# Altium schematic sheet ATK-MD0240.SchDoc
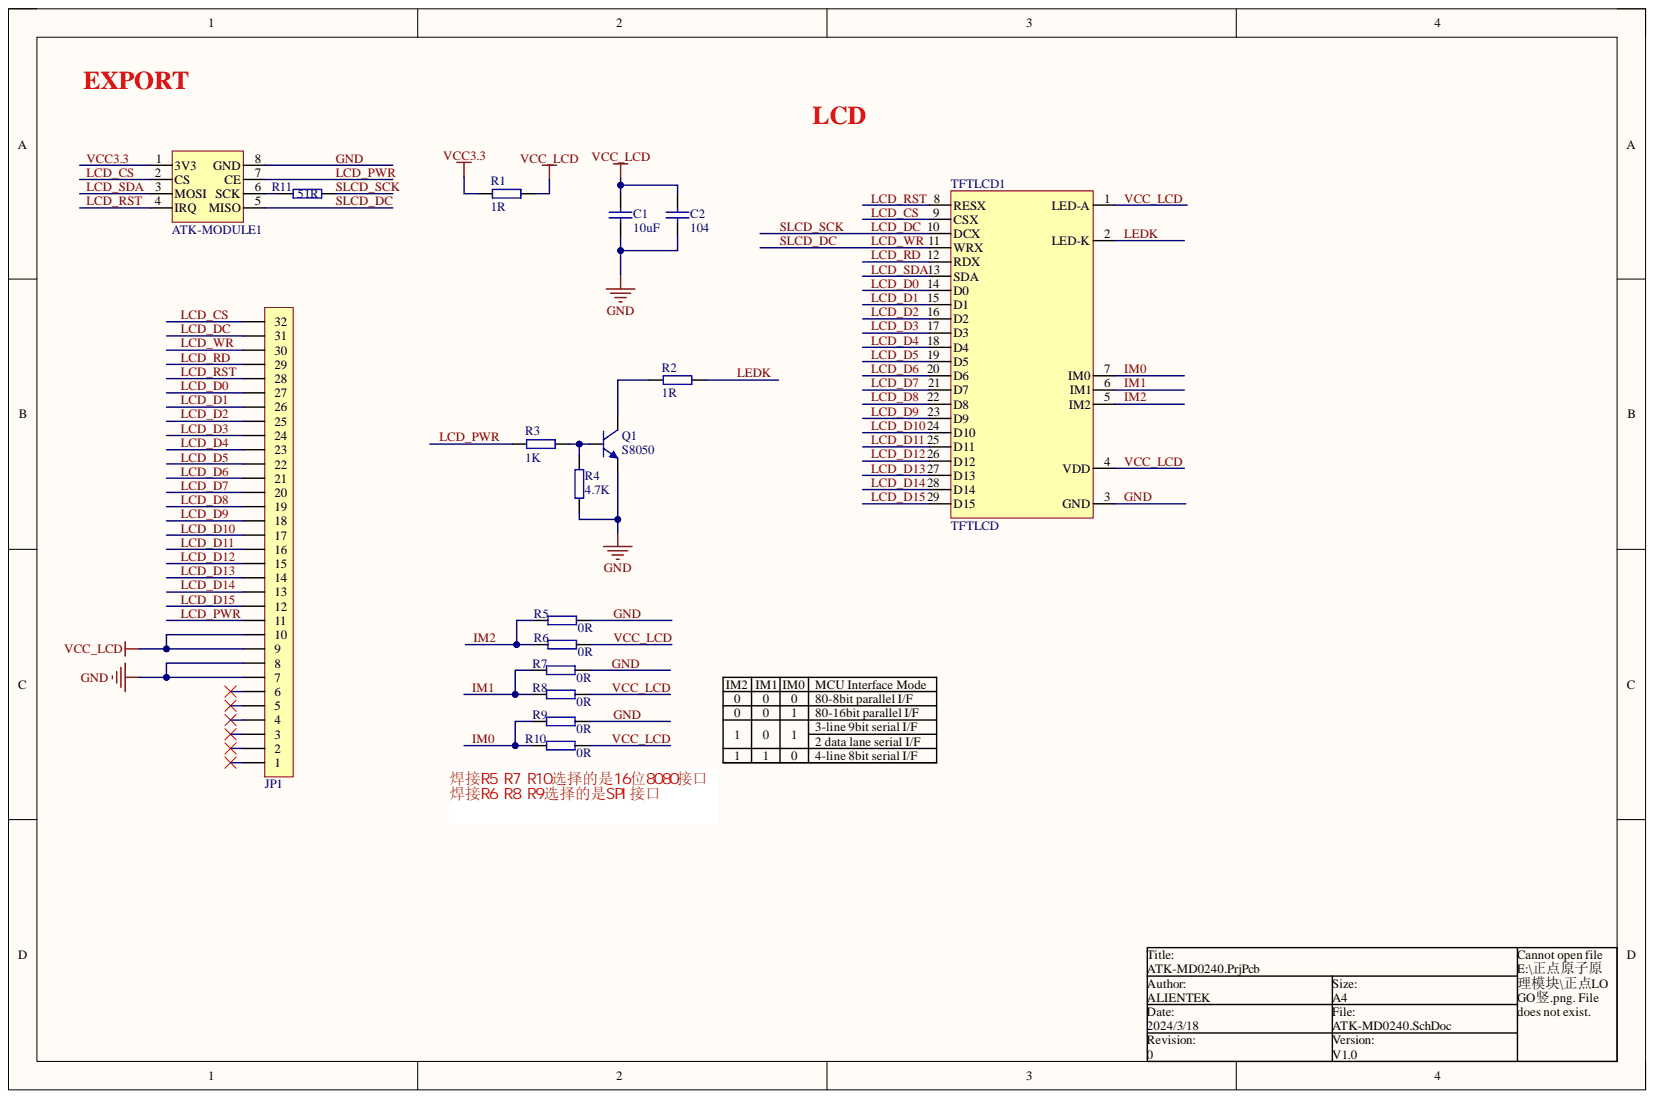
[diagram p0: ATK-MD0240.SchDoc]

## Parts
ATK-MODULE1  J-ATK-WIRELESS  [HEADER-2*4-2.54MM]  (value not drawn)
C1  10uF  [C 0402]
C2  104  [C 0402]
JP1  FPC1.0-32P  [FPC 0.5 32P H-S]  (value not drawn)
Q1  S8050  [SOT-23-3]
R1  1R  [R 0402]
R2  1R  [R 0402]
R3  1K  [R 0402]
R4  4.7K  [R 0402]
R5  0R  [R 0402]
R6  0R  [R 0402]
R7  0R  [R 0402]
R8  0R  [R 0402]
R9  0R  [R 0402]
R10  0R  [R 0402]
R11  51R  [R 0402]
TFTLCD1  TFTLCD  [SPI_LCD2.4_29pin]

## Nets
GND: ATK-MODULE1.8(GND) C1.2 C2.2 JP1.7 JP1.8 Q1.2 R4.1 R5.2 R7.2 R9.2 TFTLCD1.3(GND)
IM0: R9.1 R10.1 TFTLCD1.7(IM0)
IM1: R7.1 R8.1 TFTLCD1.6(IM1)
IM2: R5.1 R6.1 TFTLCD1.5(IM2)
LCD_CS: ATK-MODULE1.2(CS) JP1.32 TFTLCD1.9(CSX)
LCD_D0: JP1.27 TFTLCD1.14(D0)
LCD_D1: JP1.26 TFTLCD1.15(D1)
LCD_D2: JP1.25 TFTLCD1.16(D2)
LCD_D3: JP1.24 TFTLCD1.17(D3)
LCD_D4: JP1.23 TFTLCD1.18(D4)
LCD_D5: JP1.22 TFTLCD1.19(D5)
LCD_D6: JP1.21 TFTLCD1.20(D6)
LCD_D7: JP1.20 TFTLCD1.21(D7)
LCD_D8: JP1.19 TFTLCD1.22(D8)
LCD_D9: JP1.18 TFTLCD1.23(D9)
LCD_D10: JP1.17 TFTLCD1.24(D10)
LCD_D11: JP1.16 TFTLCD1.25(D11)
LCD_D12: JP1.15 TFTLCD1.26(D12)
LCD_D13: JP1.14 TFTLCD1.27(D13)
LCD_D14: JP1.13 TFTLCD1.28(D14)
LCD_D15: JP1.12 TFTLCD1.29(D15)
LCD_DC: JP1.31 R11.2 TFTLCD1.10(DCX)
LCD_PWR: ATK-MODULE1.7(CE) JP1.11 R3.1
LCD_RD: JP1.29 TFTLCD1.12(RDX)
LCD_RST: ATK-MODULE1.4(IRQ) JP1.28 TFTLCD1.8(RESX)
LCD_SDA: ATK-MODULE1.3(MOSI) TFTLCD1.13(SDA)
LCD_WR: ATK-MODULE1.5(MISO) JP1.30 TFTLCD1.11(WRX)
LEDK: R2.2 TFTLCD1.2(LED-K)
VCC3.3: ATK-MODULE1.1(3V3) R1.1
VCC_LCD: C1.1 C2.1 JP1.9 JP1.10 R1.2 R6.2 R8.2 R10.2 TFTLCD1.1(LED-A) TFTLCD1.4(VDD)
NetATK-MODULE1_6: ATK-MODULE1.6(SCK) R11.1
NetQ1_1: Q1.1 R3.2 R4.2
NetQ1_3: Q1.3 R2.1

Not connected: JP1 1 2 3 4 5 6

## Library data of the parts
ATK-MODULE1 = J-ATK-WIRELESS: ALIENTEK无线接口; Component Kind: Standard; Library Name: ATK-MD0240.SCHLIB
C1 C2 = CAP: 电容; Component Kind: Standard; Library Name: ATK-MD0240.SCHLIB
JP1 = FPC-32P: FPC连接器; 版权归属: 源创客; BOM名称: FPC连接器; Component Kind: Standard; Library Name: ATK-MD0280 V3.0.SCHLIB; 学习资源: www.ooocke.com
Q1 = S8050-SMD: 三极管S8050; Component Kind: Standard; Library Name: ATK-MD0240.SCHLIB
R1-R11 = R-0603-SMD: 贴片电阻; Component Kind: Standard; Library Name: ATK-MD0240.SCHLIB
TFTLCD1 = TFTLCD_320*240: TFTLCD,320240,spi,mcu; Component Kind: Standard; Library Name: ATK-MD0240.SCHLIB
